# Altium schematic sheet MB989_TFT_LCD_2.8inch.SchDoc(MB989_TFT_LCD_2.8inch)
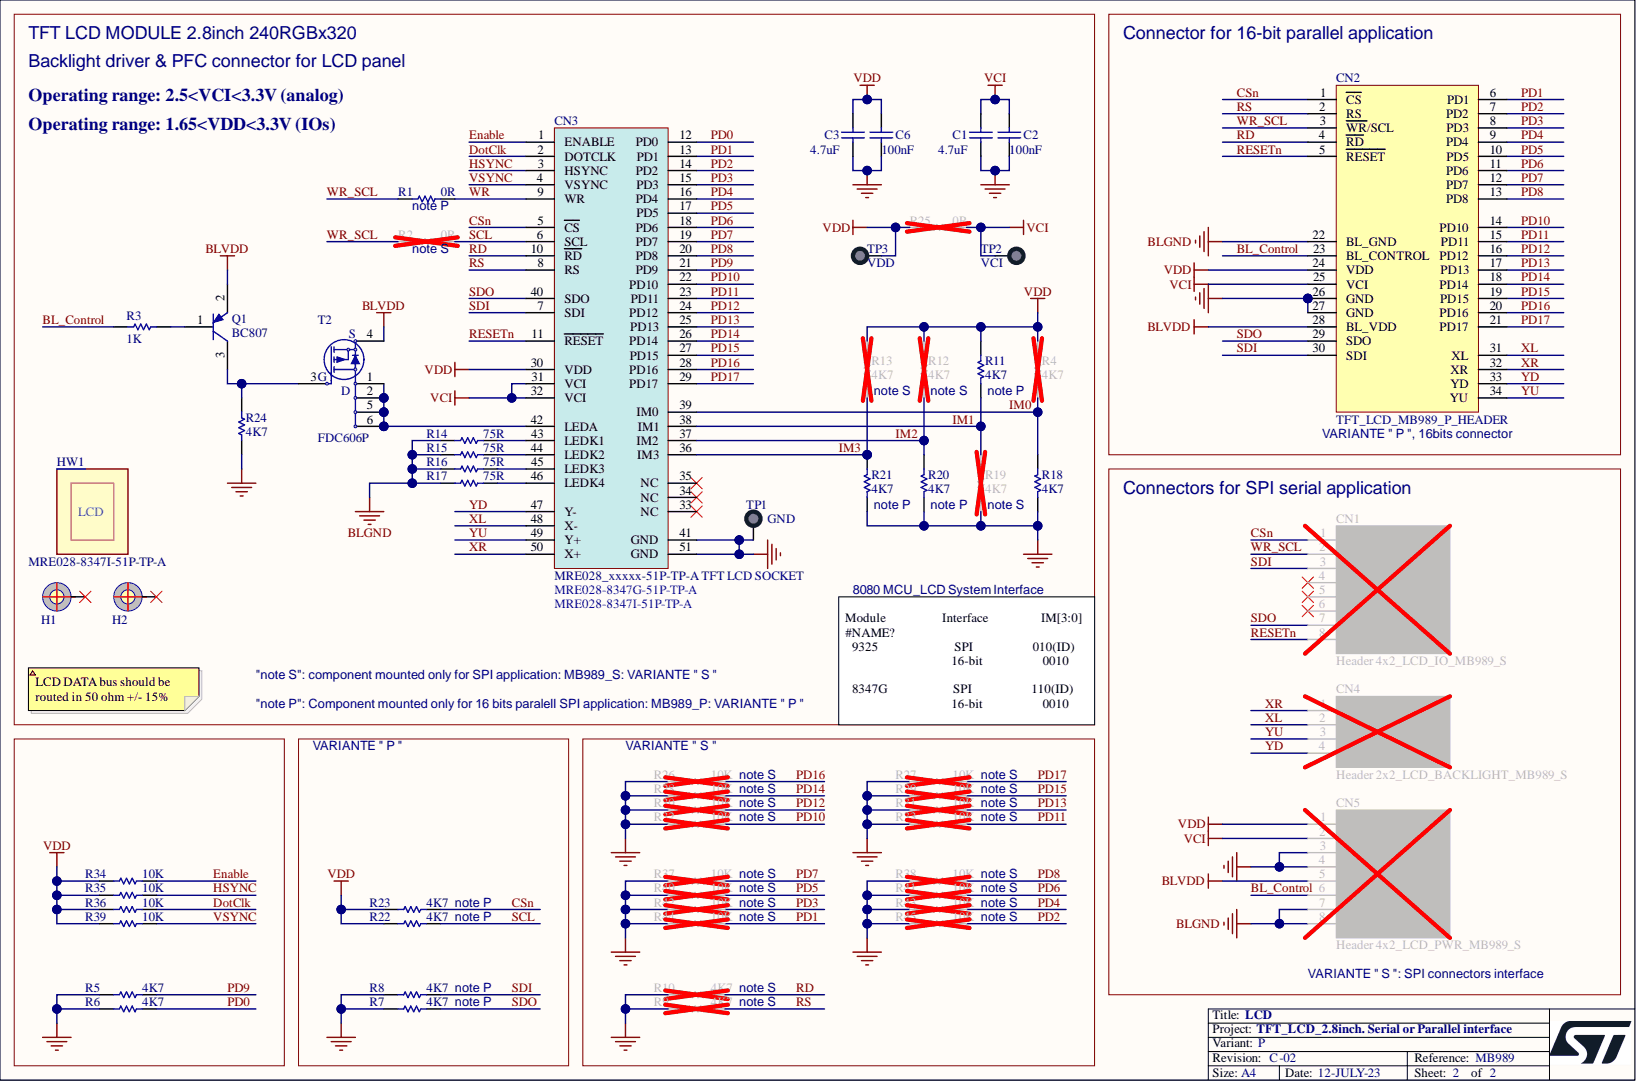
[diagram p0: MB989_TFT_LCD_2.8inch.SchDoc]

## Parts
C1  value 4.7uF  [C0603_H0P8]  (hidden comment: Capacitor)
C2  value 100nF  [C0402]  (hidden comment: Capacitor)
C3  value 4.7uF  [C0603_H0P8]  (hidden comment: Capacitor)
C6  value 100nF  [C0402]  (hidden comment: Capacitor)
CN1  Header 4x2_LCD_IO_MB989_S  [CON_SAMTEC_TSW-104-07-X-D_INV]
CN2  value TFT_LCD_MB989_P_HEADER  [CON_SAMTEC_TSW-117-07-X-D_INV]  (hidden comment: Header 17x2_LCD_TFT_MB989_P)
CN3  value MRE028_xxxxx-51P-TP-A TFT LCD SOCKET  [CON_OMRON_XF2B-5145-31A_INV]  (hidden comment: SOCKET_MRE028_xxxxx-51P-TP-A)
CN4  Header 2x2_LCD_BACKLIGHT_MB989_S  [CON_SAMTEC_TSW-102-07-X-D]
CN5  Header 4x2_LCD_PWR_MB989_S  [CON_SAMTEC_TSW-104-07-X-D_INV]
H1  POSITION HOLE  [NPTH_350]  (value not drawn)  (no BOM)
H2  POSITION HOLE  [NPTH_350]  (value not drawn)  (no BOM)
HW1  LCD_MODULE  value MRE028-8347I-51P-TP-A  Manufacturer_Part_Number: MRE028-8347I-51P-TP-A  [LCD_DRAWING_PROJET-MB989]
Q1  value BC807  Alternate_Manufacturer_Part_Number: BC807  [ICC_SOT23-3L_H1P12]  (hidden comment: PNP_SOT23)
R1  value 0R  [R0603]  (hidden comment: Resistor)
R2  value 0R  [R0603]  (hidden comment: Resistor)
R3  value 1K  [R0603]  (hidden comment: Resistor)
R4  value 4K7  [R0603]  (hidden comment: Resistor)
R5  value 4K7  [R0603]  (hidden comment: Resistor)
R6  value 4K7  [R0603]  (hidden comment: Resistor)
R7  value 4K7  [R0603]  (hidden comment: Resistor)
R8  value 4K7  [R0603]  (hidden comment: Resistor)
R9  value 4K7  [R0603]  (hidden comment: Resistor)
R10  value 4K7  [R0603]  (hidden comment: Resistor)
R11  value 4K7  [R0603]  (hidden comment: Resistor)
R12  value 4K7  [R0603]  (hidden comment: Resistor)
R13  value 4K7  [R0603]  (hidden comment: Resistor)
R14  value 75R  [R0603]  (hidden comment: Resistor)
R15  value 75R  [R0603]  (hidden comment: Resistor)
R16  value 75R  [R0603]  (hidden comment: Resistor)
R17  value 75R  [R0603]  (hidden comment: Resistor)
R18  value 4K7  [R0603]  (hidden comment: Resistor)
R19  value 4K7  [R0603]  (hidden comment: Resistor)
R20  value 4K7  [R0603]  (hidden comment: Resistor)
R21  value 4K7  [R0603]  (hidden comment: Resistor)
R22  value 4K7  [R0603]  (hidden comment: Resistor)
R23  value 4K7  [R0603]  (hidden comment: Resistor)
R24  value 4K7  [R0603]  (hidden comment: Resistor)
R25  value 0R  [R0603]  (hidden comment: Resistor)
R26  value 10K  [R0402]  (hidden comment: Resistor)
R27  value 10K  [R0402]  (hidden comment: Resistor)
R28  value 10K  [R0402]  (hidden comment: Resistor)
R29  value 10K  [R0402]  (hidden comment: Resistor)
R30  value 10K  [R0402]  (hidden comment: Resistor)
R31  value 10K  [R0402]  (hidden comment: Resistor)
R32  value 10K  [R0402]  (hidden comment: Resistor)
R33  value 10K  [R0402]  (hidden comment: Resistor)
R34  value 10K  [R0402]  (hidden comment: Resistor)
R35  value 10K  [R0402]  (hidden comment: Resistor)
R36  value 10K  [R0402]  (hidden comment: Resistor)
R37  value 10K  [R0402]  (hidden comment: Resistor)
R38  value 10K  [R0402]  (hidden comment: Resistor)
R39  value 10K  [R0402]  (hidden comment: Resistor)
R40  value 10K  [R0402]  (hidden comment: Resistor)
R41  value 10K  [R0402]  (hidden comment: Resistor)
R42  value 10K  [R0402]  (hidden comment: Resistor)
R43  value 10K  [R0402]  (hidden comment: Resistor)
R44  value 10K  [R0402]  (hidden comment: Resistor)
R45  value 10K  [R0402]  (hidden comment: Resistor)
T2  FDC606P  [MOS_SOT23-6L]
TP1  value GND  [TP_PTH_1MM_2MM]  (hidden comment: Test_Point_PTH_1mm_2mm)
TP2  value VCI  [TP_PTH_1MM_2MM]  (hidden comment: Test_Point_PTH_1mm_2mm)
TP3  value VDD  [TP_PTH_1MM_2MM]  (hidden comment: Test_Point_PTH_1mm_2mm)

## Nets
BLGND: CN2.22(BL_GND) CN5.7(BL_GND) CN5.8(BL_GND) R14.1 R15.1 R16.1 R17.1
BLVDD: CN2.28(BL_VDD) CN5.5(BL_VDD) Q1.2 T2.4
GND: C1.1 C2.1 C3.1 C6.1 CN2.26(GND) CN2.27(GND) CN3.41(GND) CN3.51(GND) CN5.3(GND) CN5.4(GND) R5.2 R6.2 R7.2 R8.2 R9.2 R10.2 R18.1 R19.1 R20.1 R21.1 R24.1 R26.1 R27.1 R28.1 R29.1 R30.1 R31.1 R32.1 R33.1 R37.1 R38.1 R40.1 R41.1 R42.1 R43.1 R44.1 R45.1 TP1.1
VCI: C1.2 C2.2 CN2.25(VCI) CN3.31(VCI) CN3.32(VCI) CN5.2(VCI) R25.2 TP2.1
VDD: C3.2 C6.2 CN2.24(VDD) CN3.30(VDD) CN5.1(VDD) R4.2 R11.2 R12.2 R13.2 R22.2 R23.2 R25.1 R34.1 R35.1 R36.1 R39.1 TP3.1
BL_Control: CN2.23(BL_CONTROL) CN5.6(BL_Control) R3.1
CSn: CN1.1(CS) CN2.1(CS) CN3.5(CS) R23.1
DotClk: CN3.2(DOTCLK) R36.2
Enable: CN3.1(ENABLE) R34.2
HSYNC: CN3.3(HSYNC) R35.2
IM0: CN3.39(IM0) R4.1 R18.2
IM1: CN3.38(IM1) R11.1 R19.2
IM2: CN3.37(IM2) R12.1 R20.2
IM3: CN3.36(IM3) R13.1 R21.2
PD0: CN3.12(PD0) R6.1
PD1: CN2.6(PD1) CN3.13(PD1) R44.2
PD2: CN2.7(PD2) CN3.14(PD2) R45.2
PD3: CN2.8(PD3) CN3.15(PD3) R42.2
PD4: CN2.9(PD4) CN3.16(PD4) R43.2
PD5: CN2.10(PD5) CN3.17(PD5) R40.2
PD6: CN2.11(PD6) CN3.18(PD6) R41.2
PD7: CN2.12(PD7) CN3.19(PD7) R37.2
PD8: CN2.13(PD8) CN3.20(PD8) R38.2
PD9: CN3.21(PD9) R5.1
PD10: CN2.14(PD10) CN3.22(PD10) R32.2
PD11: CN2.15(PD11) CN3.23(PD11) R33.2
PD12: CN2.16(PD12) CN3.24(PD12) R30.2
PD13: CN2.17(PD13) CN3.25(PD13) R31.2
PD14: CN2.18(PD14) CN3.26(PD14) R28.2
PD15: CN2.19(PD15) CN3.27(PD15) R29.2
PD16: CN2.20(PD16) CN3.28(PD16) R26.2
PD17: CN2.21(PD17) CN3.29(PD17) R27.2
RD: CN2.4(RD) CN3.10(RD) R10.1
RESETn: CN1.8(RESET) CN2.5(RESET) CN3.11(RESET)
RS: CN2.2(RS) CN3.8(RS) R9.1
SCL: CN3.6(SCL) R2.2 R22.1
SDI: CN1.3(SDI) CN2.30(SDI) CN3.7(SDI) R8.1
SDO: CN1.7(SDO) CN2.29(SDO) CN3.40(SDO) R7.1
VSYNC: CN3.4(VSYNC) R39.2
WR: CN3.9(WR) R1.2
WR_SCL: CN1.2(SCL) CN2.3(WR/SCL) R1.1 R2.1
XL: CN2.31(XL) CN3.48(X-) CN4.2(XR)
XR: CN2.32(XR) CN3.50(X+) CN4.1(XL)
YD: CN2.33(YD) CN3.47(Y-) CN4.4(YU)
YU: CN2.34(YU) CN3.49(Y+) CN4.3(YD)
NetCN3_42: CN3.42(LEDA) T2.1 T2.2 T2.5 T2.6
NetCN3_43: CN3.43(LEDK1) R14.2
NetCN3_44: CN3.44(LEDK2) R15.2
NetCN3_45: CN3.45(LEDK3) R16.2
NetCN3_46: CN3.46(LEDK4) R17.2
NetQ1_1: Q1.1 R3.2
NetQ1_3: Q1.3 R24.2 T2.3

Not connected: CN1 4(RS) 5(WR) 6(RD); CN3 33(NC) 34(NC) 35(NC); H1 1; H2 1

## Library data of the parts
C1 C3 = MCD-03342: Capacitor, MLCC, 4.7uF, 10V, X5R, +/-20%, 0603, T=0.8mm; Manufacturer: YAGEO; Manufacturer_Part_Number: CC0603MRX5R6BB475; Part_Preference: Recommended; Component_Country_Of_Origin: China, Taiwan; Database Table Name: MCD_PARTS$; ID: 5458; ShowFields: YES; Component Kind: Standard; ComponentLink1URL: http://tunmcdsvn.tun.st.com/Component_Documentation_Library/Trunk/Capacitor/YAGEO_X5R_Series/; Internal_Part_Number: MCD-03342; Library Name: MCD_PARTS_database.DbLib; Order_Code_Digikey: 311-1820-2-ND; Order_Code_Farnell: 3369257; Order_Code_RS: N/A; Package: 0603; Part_Type: Capacitor; Status: Checked
C2 C6 = MCD-00006: Capacitor, MLCC, 100nF, 16V, X7R, 10%, 0402, T=0.5mm; Alternate_Manufacturer: MURATA; Alternate_Manufacturer_Part_Number: GCM155R71C104KA55D; Manufacturer: YAGEO; Manufacturer_Part_Number: CC0402KRX7R7BB104; Database Table Name: MCD_PARTS$; Provided_By: SUBCO; Component Kind: Standard; ComponentLink1Description: Datasheet; ComponentLink1URL: http://tunmcdsvn.tun.st.com/Component_Datasheet_Library/Trunk/Capacitors/Yageo_Multilayer_Capacitor_X7R_series_100pF_to_22uF; Internal_Part_Number: MCD-00006; Library Name: MCD_PARTS_database.DbLib; Package: C0402; Part_Type: Capacitor; Status: Released
CN1 = MCD-01658: Header, 4x1, 2,54mm, VR, TH, Height 5.84mm/2.54mm, for TFT LCD MODULE MB989_S: pinning inverted; Manufacturer: SAMTEC; Manufacturer_Part_Number: TSW-104-07-G-D; Part_Type: Connector; Database Table Name: MCD_PARTS$; Provided_By: SUBCO; Component Kind: Standard; ComponentLink1Description: Datasheet; ComponentLink1URL: http://tunmcdsvn.tun.st.com/Component_Datasheet_Library/Trunk/Connectors/Samtec_TSW-HTSW-series; Internal_Part_Number: MCD-01658; Library Name: MCD_PARTS_database.DbLib; Status: Checked; Value: Header 4x2_LCD_IO_MB989_S
CN2 = MCD-01343: Header, 17x2, 2,54mm, VR, TH, Height 5.84mm/2.54mm for TFT_LCD MB989_P; Manufacturer: SAMTEC; Manufacturer_Part_Number: TSW-117-07-G-D; Part_Type: Connector; Database Table Name: MCD_PARTS$; Provided_By: SUBCO; Component Kind: Standard; ComponentLink1Description: Datasheet; ComponentLink1URL: http://tunmcdsvn.tun.st.com/Component_Datasheet_Library/Trunk/Connectors/Samtec_TSW-HTSW-series; Internal_Part_Number: MCD-01343; Library Name: MCD_PARTS_database.DbLib; Status: Checked
CN3 = MCD-01657: FCP connector for MRE028_xxxxx-51P-TP-A TFT LCD 2.8inch 320x240; Manufacturer: OMRON; Manufacturer_Part_Number: XF2B-5145-31A; Database Table Name: MCD_PARTS$; Provided_By: SUBCO; Value: MRE028_xxxxx-51P-TP-A TFT LCD SOCKET; Component Kind: Standard; ComponentLink1Description: Datasheet; ComponentLink1URL: http://tunmcdsvn.tun.st.com/Component_Datasheet_Library/Trunk/Connectors/OMRON_Connector_XF2B_series; Internal_Part_Number: MCD-01657; Library Name: MCD_PARTS_database.DbLib; Part_Type: Connector; Status: Checked
CN4 = MCD-01665: Header, 2x2, 2,54mm, VR, TH, Height 5.84mm/2.54mm, for TFT LCD MB989_P: Backlight; Manufacturer: SAMTEC; Manufacturer_Part_Number: TSW-102-07-G-D; Part_Type: Connector; Database Table Name: MCD_PARTS$; Provided_By: SUBCO; Component Kind: Standard; ComponentLink1Description: Datasheet; ComponentLink1URL: http://tunmcdsvn.tun.st.com/Component_Datasheet_Library/Trunk/Connectors/Samtec_TSW-HTSW-series; Internal_Part_Number: MCD-01665; Library Name: MCD_PARTS_database.DbLib; Status: Checked; Value: Header 2x2_LCD_BACKLIGHT_MB989_S
CN5 = MCD-01659: Header, 4x2, 2,54mm, VR, TH, Height 5.84mm/2.54mm for TFT LCD MODULE MB989_S: pinning inverted; Manufacturer: SAMTEC; Manufacturer_Part_Number: TSW-104-07-G-D; Part_Type: Connector; Database Table Name: MCD_PARTS$; Provided_By: SUBCO; Component Kind: Standard; ComponentLink1Description: Datasheet; ComponentLink1URL: http://tunmcdsvn.tun.st.com/Component_Datasheet_Library/Trunk/Connectors/Samtec_TSW-HTSW-series; Internal_Part_Number: MCD-01659; Library Name: MCD_PARTS_database.DbLib; Status: Checked; Value: Header 4x2_LCD_PWR_MB989_S
H1 H2 = MCD-01368: POSITION HOLE C3R5D3R5; Part_Type: Mechanical; Database Table Name: MCD_PARTS$; ComponentLink1Description: Datasheet; Internal_Part_Number: MCD-01368; Library Name: MCD_PARTS_database.DbLib; Manufacturer: n/a; Manufacturer_Part_Number: n/a; Provided_By: n/a; Status: Checked
HW1 = MCD-01419: Display 2.8inch SPI or 16-bit parallel; BMS_Part_Number: 8001057; Manufacturer: SHANGHAI MOORING ELECTRONICS TECHNOLOGY; Database Table Name: MCD_PARTS$; Provided_By: ST; Component Kind: Standard; ComponentLink1Description: Datasheet; ComponentLink1URL: http://tunmcdsvn.tun.st.com/Component_Datasheet_Library/Trunk/Display/Shanghai_Mooring_Electronics_Technology_LCD_MRE028; Internal_Part_Number: MCD-01419; Library Name: MCD_PARTS_database.DbLib; Part_Type: Display; Status: Checked
Q1 = MCD-01527: Transistor Bipolar PNP, 45V, 500mA, 100MHz, 300mW, SOT23-3; Alternate_Manufacturer: NXP; Manufacturer: NEXPERIA; Part_Type: IC; Database Table Name: MCD_PARTS$; Provided_By: SUBCO; Component Kind: Standard; ComponentLink1Description: Datasheet; ComponentLink1URL: http://tunmcdsvn.tun.st.com/Component_Datasheet_Library/Trunk/IC/NEXPERIA_Transistor_BC807/NEXPERIA_BC807_BC807W_BC327.pdf; Internal_Part_Number: MCD-01527; Library Name: MCD_PARTS_database.DbLib; Manufacturer_Part_Number: BC807; Package: PNP_SOT23; Status: Footprint available
R1 R2 R25 = MCD-00056: Resistor,0R,0603,5%,1/10W; Manufacturer: YAGEO; Manufacturer_Part_Number: RC0603JR-070RL; Database Table Name: MCD_PARTS$; Provided_By: SUBCO; Component Kind: Standard; ComponentLink1Description: Datasheet; ComponentLink1URL: http://tunmcdsvn.tun.st.com/Component_Datasheet_Library/Trunk/Resistors/Yageo_Resistor_RC0603-series; Internal_Part_Number: MCD-00056; Library Name: MCD_PARTS_database.DbLib; Package: R0603; Part_Type: Resistor; Status: Released
R3 = MCD-00070: Resistor,1K,0603,1%,1/10W; Manufacturer: YAGEO; Manufacturer_Part_Number: RC0603FR-071KL; Database Table Name: MCD_PARTS$; Provided_By: SUBCO; Component Kind: Standard; ComponentLink1Description: Datasheet; ComponentLink1URL: http://tunmcdsvn.tun.st.com/Component_Datasheet_Library/Trunk/Resistors/Yageo_Resistor_RC0603-series; Internal_Part_Number: MCD-00070; Library Name: MCD_PARTS_database.DbLib; Package: R0603; Part_Type: Resistor; Status: Released
R4-R13 R18-R24 = MCD-00219: Resistor,4K7,0603,1%,1/10W; Manufacturer: YAGEO; Manufacturer_Part_Number: RC0603FR-074K7L; Database Table Name: MCD_PARTS$; Provided_By: SUBCO; Component Kind: Standard; ComponentLink1Description: Datasheet; ComponentLink1URL: http://tunmcdsvn.tun.st.com/Component_Datasheet_Library/Trunk/Resistors/Yageo_Resistor_RC0603-series; Internal_Part_Number: MCD-00219; Library Name: MCD_PARTS_database.DbLib; Package: R0603; Part_Type: Resistor; Status: Released
R14-R17 = MCD-00516: Resistor,75R,0603,1%,1/10W; BMS_Part_Number: 9129726; Manufacturer: YAGEO; Manufacturer_Part_Number: RC0603FR-0775RL; Database Table Name: MCD_PARTS$; Provided_By: SUBCO; Component Kind: Standard; ComponentLink1Description: Datasheet; ComponentLink1URL: http://tunmcdsvn.tun.st.com/Component_Datasheet_Library/Trunk/Resistors/Yageo_Resistor_RC0603-series; Internal_Part_Number: MCD-00516; Library Name: MCD_PARTS_database.DbLib; Package: R0603; Part_Type: Resistor; Status: Released
R26-R45 = MCD-00053: Resistor,10K,0402,1%,1/16W; Manufacturer: YAGEO; Manufacturer_Part_Number: RC0402FR-0710KL; Database Table Name: MCD_PARTS$; Provided_By: SUBCO; Component Kind: Standard; ComponentLink1Description: Datasheet; ComponentLink1URL: http://tunmcdsvn.tun.st.com/Component_Datasheet_Library/Trunk/Resistors/Yageo_Resistor_RC0402-series; Internal_Part_Number: MCD-00053; Library Name: MCD_PARTS_database.DbLib; Package: R0402; Part_Type: Resistor; Status: Released
T2 = MCD-01655: MOSFET, P-Channel , Vgs(th): -1.5V, Id: -6A, Vds(max): -12V, Vgs(max): +/-8V, Rdson:26mohm@Vgs=-4.5V; Manufacturer: ON SEMICONDUCTOR; Part_Type: IC; Database Table Name: MCD_PARTS$; Provided_By: SUBCO; Component Kind: Standard; ComponentLink1Description: Datasheet; ComponentLink1URL: http://tunmcdsvn.tun.st.com/Component_Datasheet_Library/Trunk/IC/ON_SEMICONDUCTOR_MOSFET_P_FDC606P; Internal_Part_Number: MCD-01655; Library Name: MCD_PARTS_database.DbLib; Manufacturer_Part_Number: FDC606P; Package: SOT23-6L; Status: Footprint available; Value: FDC606P
TP1-TP3 = MCD-01506: Test Point trought hole 1mm/2mm; Part_Type: Mechanical; Database Table Name: MCD_PARTS$; Component Kind: Standard; ComponentLink1Description: Datasheet; ComponentLink1URL: n/a; Internal_Part_Number: MCD-01506; Library Name: MCD_PARTS_database.DbLib; Manufacturer: n/a; Manufacturer_Part_Number: n/a; Provided_By: n/a; Status: Released
